# Altium schematic sheet Top.SchDoc(U_Top)
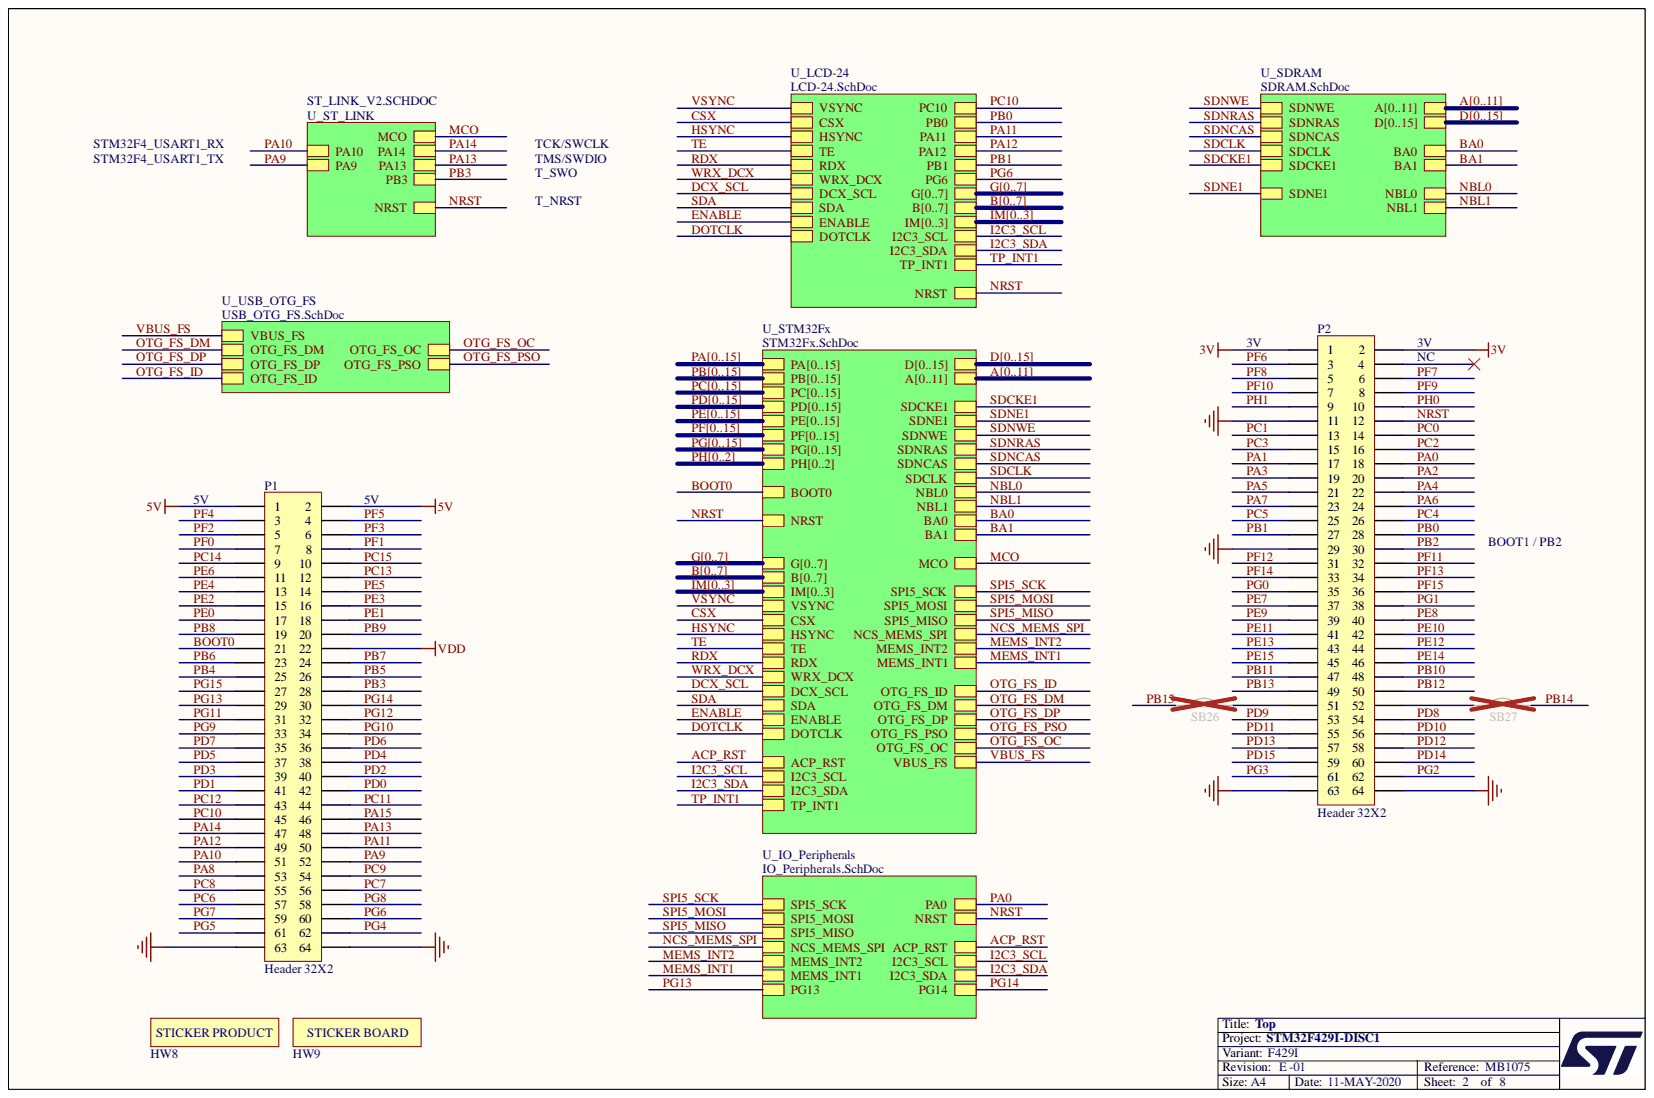
[diagram p0: Top.SchDoc]

## Parts
HW8  STICKER_PRODUCT  (no BOM)
HW9  STICKER_BOARD  (no BOM)
P1  Header 32X2  [HDR2X32]
P2  Header 32X2  [HDR2X32]
SB26  Circuit Breaker  [JP2_SMD]  (value not drawn)
SB27  Circuit Breaker  [JP2_SMD]  (value not drawn)

## Nets
3V: P2.1 P2.2
5V: P1.1 P1.2
GND: P1.63 P1.64 P2.11 P2.29 P2.63 P2.64
VDD: P1.22
BOOT0: P1.21
NRST: P2.12
PA0: P2.18  [also labelled PA]
PA1: P2.17  [also labelled PA]
PA2: P2.20  [also labelled PA]
PA3: P2.19  [also labelled B]
PA3: P2.19  [also labelled B]
PA4: P2.22  [also labelled PA]
PA5: P2.21  [also labelled PA]
PA6: P2.24  [also labelled G]
PA7: P2.23  [also labelled PA]
PA8: P1.53  [also labelled PA]
PA9: P1.52  [also labelled PA]
PA10: P1.51  [also labelled PA]
PA11: P1.50  [also labelled PA]
PA12: P1.49  [also labelled PA]
PA13: P1.48  [also labelled PA]
PA14: P1.47  [also labelled PA]
PA15: P1.46  [also labelled PA]
PB0: P2.28  [also labelled PB]
PB1: P2.27  [also labelled PB]
PB2: P2.30  [also labelled PB]
PB3: P1.28  [also labelled PB]
PB4: P1.25  [also labelled PB]
PB5: P1.26  [also labelled PB]
PB6: P1.23  [also labelled PB]
PB7: P1.24  [also labelled PB]
PB8: P1.19  [also labelled B]
PB8: P1.19  [also labelled B]
PB9: P1.20  [also labelled B]
PB9: P1.20  [also labelled B]
PB10: P2.48  [also labelled G]
PB11: P2.47  [also labelled G]
PB12: P2.50  [also labelled PB]
PB13: P2.49  [also labelled PB]
PB14: SB27.2  [also labelled PB]
PB15: SB26.1  [also labelled PB]
PC0: P2.14  [also labelled PC]
PC1: P2.13  [also labelled PC]
PC2: P2.16  [also labelled PC]
PC3: P2.15  [also labelled PC]
PC4: P2.26  [also labelled PC]
PC5: P2.25  [also labelled PC]
PC6: P1.57  [also labelled PC]
PC7: P1.56  [also labelled G]
PC8: P1.55  [also labelled PC]
PC9: P1.54  [also labelled PC]
PC10: P1.45  [also labelled PC]
PC11: P1.44  [also labelled PC]
PC12: P1.43  [also labelled PC]
PC13: P1.12  [also labelled PC]
PC14: P1.9  [also labelled PC]
PC15: P1.10  [also labelled PC]
PD0: P1.42  [also labelled D]
PD1: P1.41  [also labelled D]
PD2: P1.40  [also labelled PD]
PD3: P1.39  [also labelled G]
PD4: P1.38  [also labelled PD]
PD5: P1.37  [also labelled PD]
PD6: P1.36  [also labelled B]
PD7: P1.35  [also labelled PD]
PD8: P2.54  [also labelled D]
PD9: P2.53  [also labelled D]
PD10: P2.56  [also labelled D]
PD11: P2.55  [also labelled PD]
PD12: P2.58  [also labelled PD]
PD13: P2.57  [also labelled PD]
PD14: P2.60  [also labelled D]
PD15: P2.59  [also labelled D]
PE0: P1.17  [also labelled PE]
PE1: P1.18  [also labelled PE]
PE2: P1.15  [also labelled PE]
PE3: P1.16  [also labelled PE]
PE4: P1.13  [also labelled PE]
PE5: P1.14  [also labelled PE]
PE6: P1.11  [also labelled PE]
PE7: P2.37  [also labelled D]
PE7: P2.37  [also labelled D]
PE8: P2.40  [also labelled D]
PE8: P2.40  [also labelled D]
PE9: P2.39  [also labelled D]
PE9: P2.39  [also labelled D]
PE10: P2.42  [also labelled D]
PE10: P2.42  [also labelled D]
PE11: P2.41  [also labelled D]
PE11: P2.41  [also labelled D]
PE12: P2.44  [also labelled D]
PE12: P2.44  [also labelled D]
PE13: P2.43  [also labelled D]
PE13: P2.43  [also labelled D]
PE14: P2.46  [also labelled D]
PE14: P2.46  [also labelled D]
PE15: P2.45  [also labelled D]
PE15: P2.45  [also labelled D]
PF0: P1.7  [also labelled A]
PF1: P1.8  [also labelled A]
PF2: P1.5  [also labelled A]
PF3: P1.6  [also labelled A]
PF4: P1.3  [also labelled A]
PF5: P1.4  [also labelled A]
PF6: P2.3  [also labelled PF]
PF7: P2.6  [also labelled PF]
PF8: P2.5  [also labelled PF]
PF9: P2.8  [also labelled PF]
PF10: P2.7  [also labelled PF]
PF11: P2.32  [also labelled PF]
PF12: P2.31  [also labelled A]
PF13: P2.34  [also labelled A]
PF14: P2.33  [also labelled A]
PF15: P2.36  [also labelled A]
PG0: P2.35  [also labelled A]
PG1: P2.38  [also labelled A]
PG2: P2.62  [also labelled PG]
PG3: P2.61  [also labelled PG]
PG4: P1.62  [also labelled PG]
PG5: P1.61  [also labelled PG]
PG6: P1.60  [also labelled PG]
PG7: P1.59  [also labelled PG]
PG8: P1.58  [also labelled PG]
PG9: P1.33  [also labelled PG]
PG10: P1.34  [also labelled G]
PG11: P1.31  [also labelled B]
PG12: P1.32  [also labelled B]
PG13: P1.29  [also labelled PG]
PG14: P1.30  [also labelled PG]
PG15: P1.27  [also labelled PG]
PH0: P2.10  [also labelled PH]
PH1: P2.9  [also labelled PH]
NetP2_4: P2.4
NetP2_51: P2.51 SB26.2
NetP2_52: P2.52 SB27.1
A0:  [also labelled A]
A1:  [also labelled A]
A2:  [also labelled A]
A3:  [also labelled A]
A4:  [also labelled A]
A5:  [also labelled A]
A6:  [also labelled A]
A7:  [also labelled A]
A8:  [also labelled A]
A9:  [also labelled A]
A10:  [also labelled A]
A11:  [also labelled A]
ACP_RST:  [also labelled PA]
B0:  [also labelled B]
B1:  [also labelled B]
B2:  [also labelled B]
B3:  [also labelled B]
B4:  [also labelled B]
B5:  [also labelled B]
B6:  [also labelled B]
B7:  [also labelled B]
BA0:  [also labelled PG]
BA1:  [also labelled PG]
CSX:  [also labelled PC]
D0:  [also labelled D]
D1:  [also labelled D]
D2:  [also labelled D]
D3:  [also labelled D]
D4:  [also labelled D]
D5:  [also labelled D]
D6:  [also labelled D]
D7:  [also labelled D]
D8:  [also labelled D]
D9:  [also labelled D]
D10:  [also labelled D]
D11:  [also labelled D]
D12:  [also labelled D]
D13:  [also labelled D]
D14:  [also labelled D]
D15:  [also labelled D]
DCX_SCL:  [also labelled PF]
DOTCLK:  [also labelled PG]
ENABLE:  [also labelled PF]
G0:  [also labelled G]
G1:  [also labelled G]
G2:  [also labelled G]
G3:  [also labelled G]
G4:  [also labelled G]
G5:  [also labelled G]
G6:  [also labelled G]
G7:  [also labelled G]
HSYNC:  [also labelled PC]
I2C3_SCL:  [also labelled PA]
I2C3_SDA:  [also labelled PC]
IM0:  [also labelled IM]
IM1:  [also labelled IM]
IM2:  [also labelled IM]
IM3:  [also labelled IM]
MCO:
MEMS_INT1:  [also labelled PA]
MEMS_INT2:  [also labelled PA]
NBL0:  [also labelled PE]
NBL1:  [also labelled PE]
NCS_MEMS_SPI:  [also labelled PC]
OTG_FS_DM:  [also labelled PB]
OTG_FS_DP:  [also labelled PB]
OTG_FS_ID:  [also labelled PB]
OTG_FS_OC:  [also labelled PC]
OTG_FS_PSO:  [also labelled PC]
PH2:  [also labelled PH]
RDX:  [also labelled PD]
SDA:  [also labelled PF]
SDCKE1:  [also labelled PB]
SDCLK:  [also labelled PG]
SDNCAS:  [also labelled PG]
SDNE1:  [also labelled PB]
SDNRAS:  [also labelled PF]
SDNWE:  [also labelled PC]
SPI5_MISO:  [also labelled PF]
SPI5_MOSI:  [also labelled PF]
SPI5_SCK:  [also labelled PF]
TE:  [also labelled PD]
TP_INT1:  [also labelled PA]
VBUS_FS:  [also labelled PB]
VSYNC:  [also labelled PA]
WRX_DCX:  [also labelled PD]

## Library data of the parts
P1 P2 = Header 32X2: Header, 32-Pin, Dual row; Component Kind: Standard; LatestRevisionDate: 17-Jul-2002; LatestRevisionNote: Re-released for DXP Platform.; Library Name: MCD_TOOLS.SchLib; Signal Integrity: Connector; Publisher: Altium Limited
SB26 SB27 = Circuit Breaker: Solder: NO; Component Kind: Standard; Description: Circuit Breaker; Library Name: MCD_TOOLS.SCHLIB; Published: 24-Mar-1999; Revision: July-2002: Re-released for DXP Platform.; Publisher: Altium Limited
HW8 = STICKER_PRODUCT: Library Name: MB1075.SCHLIB
HW9 = STICKER_BOARD: Library Name: MB1075.SCHLIB
